# Altium schematic sheet CapSenseBottom.SchDoc
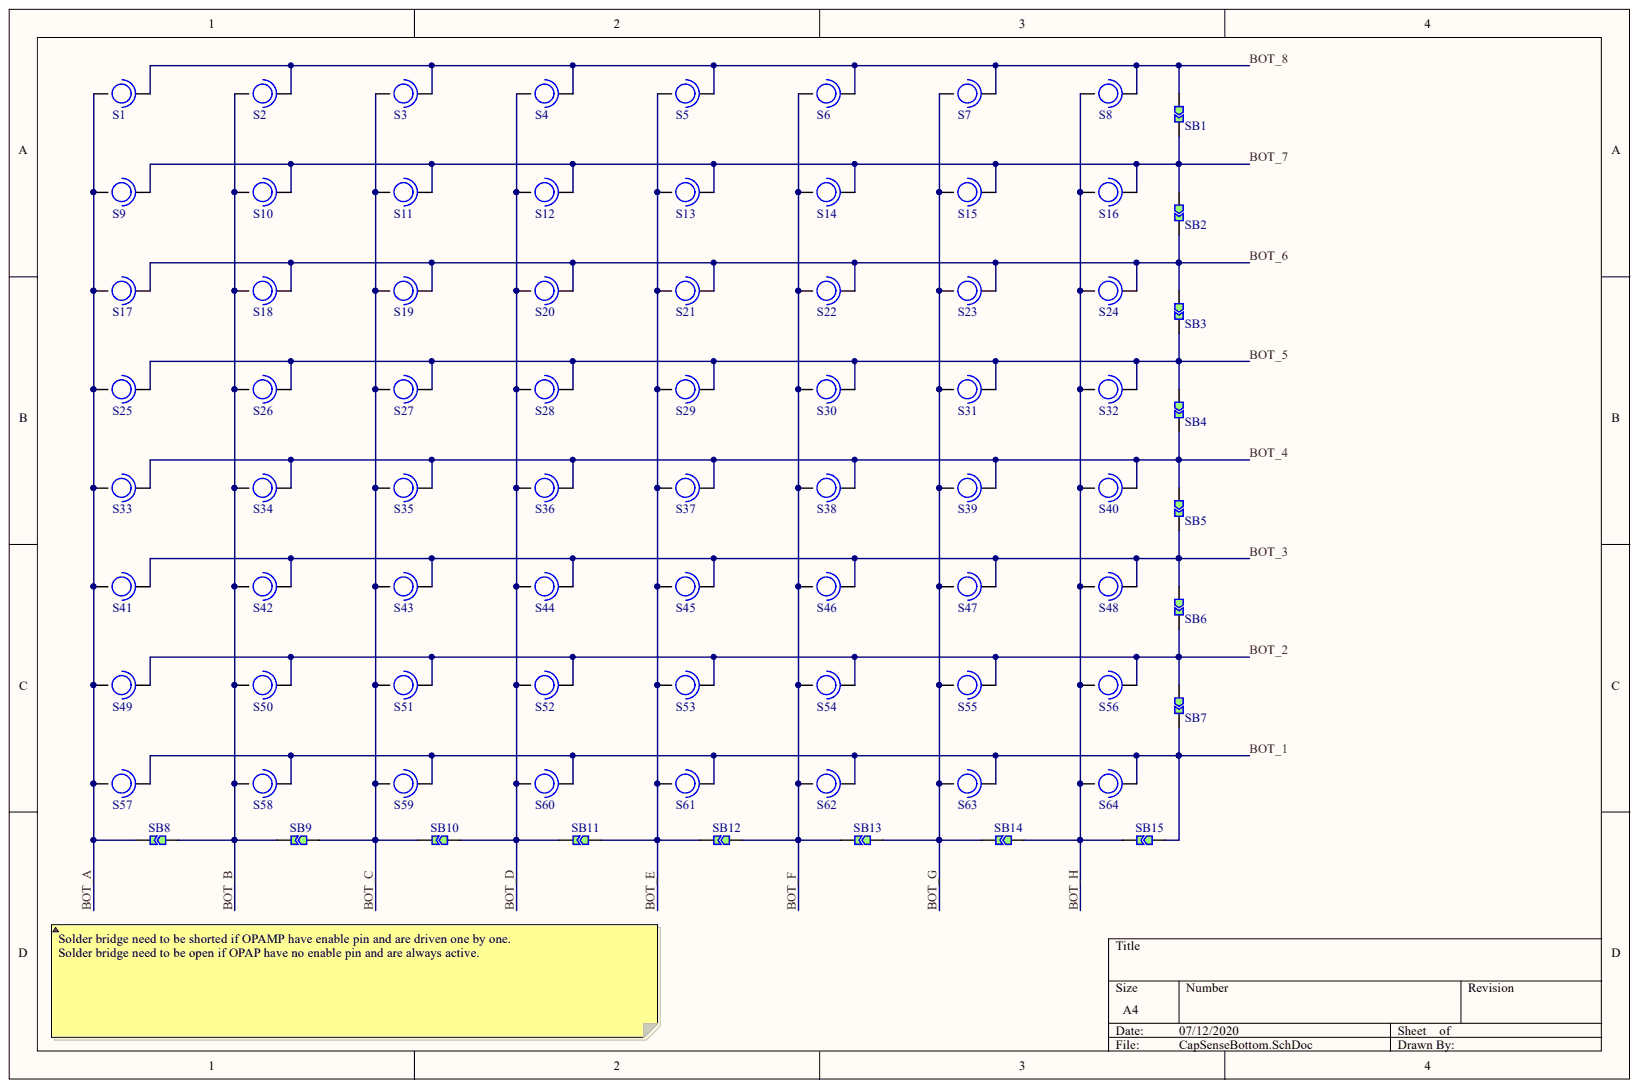
[diagram p0: CapSenseBottom.SchDoc]

## Parts
S1  sense_cap_chess_20mm  [PCB-23fkddm5n7nwthazufj0-1]  (value not drawn)
S2  sense_cap_chess_20mm  [PCB-23fkddm5n7nwthazufj0-1]  (value not drawn)
S3  sense_cap_chess_20mm  [PCB-23fkddm5n7nwthazufj0-1]  (value not drawn)
S4  sense_cap_chess_20mm  [PCB-23fkddm5n7nwthazufj0-1]  (value not drawn)
S5  sense_cap_chess_20mm  [PCB-23fkddm5n7nwthazufj0-1]  (value not drawn)
S6  sense_cap_chess_20mm  [PCB-23fkddm5n7nwthazufj0-1]  (value not drawn)
S7  sense_cap_chess_20mm  [PCB-23fkddm5n7nwthazufj0-1]  (value not drawn)
S8  sense_cap_chess_20mm  [PCB-23fkddm5n7nwthazufj0-1]  (value not drawn)
S9  sense_cap_chess_20mm  [PCB-23fkddm5n7nwthazufj0-1]  (value not drawn)
S10  sense_cap_chess_20mm  [PCB-23fkddm5n7nwthazufj0-1]  (value not drawn)
S11  sense_cap_chess_20mm  [PCB-23fkddm5n7nwthazufj0-1]  (value not drawn)
S12  sense_cap_chess_20mm  [PCB-23fkddm5n7nwthazufj0-1]  (value not drawn)
S13  sense_cap_chess_20mm  [PCB-23fkddm5n7nwthazufj0-1]  (value not drawn)
S14  sense_cap_chess_20mm  [PCB-23fkddm5n7nwthazufj0-1]  (value not drawn)
S15  sense_cap_chess_20mm  [PCB-23fkddm5n7nwthazufj0-1]  (value not drawn)
S16  sense_cap_chess_20mm  [PCB-23fkddm5n7nwthazufj0-1]  (value not drawn)
S17  sense_cap_chess_20mm  [PCB-23fkddm5n7nwthazufj0-1]  (value not drawn)
S18  sense_cap_chess_20mm  [PCB-23fkddm5n7nwthazufj0-1]  (value not drawn)
S19  sense_cap_chess_20mm  [PCB-23fkddm5n7nwthazufj0-1]  (value not drawn)
S20  sense_cap_chess_20mm  [PCB-23fkddm5n7nwthazufj0-1]  (value not drawn)
S21  sense_cap_chess_20mm  [PCB-23fkddm5n7nwthazufj0-1]  (value not drawn)
S22  sense_cap_chess_20mm  [PCB-23fkddm5n7nwthazufj0-1]  (value not drawn)
S23  sense_cap_chess_20mm  [PCB-23fkddm5n7nwthazufj0-1]  (value not drawn)
S24  sense_cap_chess_20mm  [PCB-23fkddm5n7nwthazufj0-1]  (value not drawn)
S25  sense_cap_chess_20mm  [PCB-23fkddm5n7nwthazufj0-1]  (value not drawn)
S26  sense_cap_chess_20mm  [PCB-23fkddm5n7nwthazufj0-1]  (value not drawn)
S27  sense_cap_chess_20mm  [PCB-23fkddm5n7nwthazufj0-1]  (value not drawn)
S28  sense_cap_chess_20mm  [PCB-23fkddm5n7nwthazufj0-1]  (value not drawn)
S29  sense_cap_chess_20mm  [PCB-23fkddm5n7nwthazufj0-1]  (value not drawn)
S30  sense_cap_chess_20mm  [PCB-23fkddm5n7nwthazufj0-1]  (value not drawn)
S31  sense_cap_chess_20mm  [PCB-23fkddm5n7nwthazufj0-1]  (value not drawn)
S32  sense_cap_chess_20mm  [PCB-23fkddm5n7nwthazufj0-1]  (value not drawn)
S33  sense_cap_chess_20mm  [PCB-23fkddm5n7nwthazufj0-1]  (value not drawn)
S34  sense_cap_chess_20mm  [PCB-23fkddm5n7nwthazufj0-1]  (value not drawn)
S35  sense_cap_chess_20mm  [PCB-23fkddm5n7nwthazufj0-1]  (value not drawn)
S36  sense_cap_chess_20mm  [PCB-23fkddm5n7nwthazufj0-1]  (value not drawn)
S37  sense_cap_chess_20mm  [PCB-23fkddm5n7nwthazufj0-1]  (value not drawn)
S38  sense_cap_chess_20mm  [PCB-23fkddm5n7nwthazufj0-1]  (value not drawn)
S39  sense_cap_chess_20mm  [PCB-23fkddm5n7nwthazufj0-1]  (value not drawn)
S40  sense_cap_chess_20mm  [PCB-23fkddm5n7nwthazufj0-1]  (value not drawn)
S41  sense_cap_chess_20mm  [PCB-23fkddm5n7nwthazufj0-1]  (value not drawn)
S42  sense_cap_chess_20mm  [PCB-23fkddm5n7nwthazufj0-1]  (value not drawn)
S43  sense_cap_chess_20mm  [PCB-23fkddm5n7nwthazufj0-1]  (value not drawn)
S44  sense_cap_chess_20mm  [PCB-23fkddm5n7nwthazufj0-1]  (value not drawn)
S45  sense_cap_chess_20mm  [PCB-23fkddm5n7nwthazufj0-1]  (value not drawn)
S46  sense_cap_chess_20mm  [PCB-23fkddm5n7nwthazufj0-1]  (value not drawn)
S47  sense_cap_chess_20mm  [PCB-23fkddm5n7nwthazufj0-1]  (value not drawn)
S48  sense_cap_chess_20mm  [PCB-23fkddm5n7nwthazufj0-1]  (value not drawn)
S49  sense_cap_chess_20mm  [PCB-23fkddm5n7nwthazufj0-1]  (value not drawn)
S50  sense_cap_chess_20mm  [PCB-23fkddm5n7nwthazufj0-1]  (value not drawn)
S51  sense_cap_chess_20mm  [PCB-23fkddm5n7nwthazufj0-1]  (value not drawn)
S52  sense_cap_chess_20mm  [PCB-23fkddm5n7nwthazufj0-1]  (value not drawn)
S53  sense_cap_chess_20mm  [PCB-23fkddm5n7nwthazufj0-1]  (value not drawn)
S54  sense_cap_chess_20mm  [PCB-23fkddm5n7nwthazufj0-1]  (value not drawn)
S55  sense_cap_chess_20mm  [PCB-23fkddm5n7nwthazufj0-1]  (value not drawn)
S56  sense_cap_chess_20mm  [PCB-23fkddm5n7nwthazufj0-1]  (value not drawn)
S57  sense_cap_chess_20mm  [PCB-23fkddm5n7nwthazufj0-1]  (value not drawn)
S58  sense_cap_chess_20mm  [PCB-23fkddm5n7nwthazufj0-1]  (value not drawn)
S59  sense_cap_chess_20mm  [PCB-23fkddm5n7nwthazufj0-1]  (value not drawn)
S60  sense_cap_chess_20mm  [PCB-23fkddm5n7nwthazufj0-1]  (value not drawn)
S61  sense_cap_chess_20mm  [PCB-23fkddm5n7nwthazufj0-1]  (value not drawn)
S62  sense_cap_chess_20mm  [PCB-23fkddm5n7nwthazufj0-1]  (value not drawn)
S63  sense_cap_chess_20mm  [PCB-23fkddm5n7nwthazufj0-1]  (value not drawn)
S64  sense_cap_chess_20mm  [PCB-23fkddm5n7nwthazufj0-1]  (value not drawn)
SB1  Solder Bridge 0402  [PCB-zgo6wgtf9tfvr4qcvek3-1]  (value not drawn)
SB2  Solder Bridge 0402  [PCB-zgo6wgtf9tfvr4qcvek3-1]  (value not drawn)
SB3  Solder Bridge 0402  [PCB-zgo6wgtf9tfvr4qcvek3-1]  (value not drawn)
SB4  Solder Bridge 0402  [PCB-zgo6wgtf9tfvr4qcvek3-1]  (value not drawn)
SB5  Solder Bridge 0402  [PCB-zgo6wgtf9tfvr4qcvek3-1]  (value not drawn)
SB6  Solder Bridge 0402  [PCB-zgo6wgtf9tfvr4qcvek3-1]  (value not drawn)
SB7  Solder Bridge 0402  [PCB-zgo6wgtf9tfvr4qcvek3-1]  (value not drawn)
SB8  Solder Bridge 0402  [PCB-zgo6wgtf9tfvr4qcvek3-1]  (value not drawn)
SB9  Solder Bridge 0402  [PCB-zgo6wgtf9tfvr4qcvek3-1]  (value not drawn)
SB10  Solder Bridge 0402  [PCB-zgo6wgtf9tfvr4qcvek3-1]  (value not drawn)
SB11  Solder Bridge 0402  [PCB-zgo6wgtf9tfvr4qcvek3-1]  (value not drawn)
SB12  Solder Bridge 0402  [PCB-zgo6wgtf9tfvr4qcvek3-1]  (value not drawn)
SB13  Solder Bridge 0402  [PCB-zgo6wgtf9tfvr4qcvek3-1]  (value not drawn)
SB14  Solder Bridge 0402  [PCB-zgo6wgtf9tfvr4qcvek3-1]  (value not drawn)
SB15  Solder Bridge 0402  [PCB-zgo6wgtf9tfvr4qcvek3-1]  (value not drawn)

## Nets
BOT_1: S57.2 S58.2 S59.2 S60.2 S61.2 S62.2 S63.2 S64.2 SB7.1 SB15.2
BOT_2: S49.2 S50.2 S51.2 S52.2 S53.2 S54.2 S55.2 S56.2 SB6.1 SB7.2
BOT_3: S41.2 S42.2 S43.2 S44.2 S45.2 S46.2 S47.2 S48.2 SB5.1 SB6.2
BOT_4: S33.2 S34.2 S35.2 S36.2 S37.2 S38.2 S39.2 S40.2 SB4.1 SB5.2
BOT_5: S25.2 S26.2 S27.2 S28.2 S29.2 S30.2 S31.2 S32.2 SB3.1 SB4.2
BOT_6: S17.2 S18.2 S19.2 S20.2 S21.2 S22.2 S23.2 S24.2 SB2.1 SB3.2
BOT_7: S9.2 S10.2 S11.2 S12.2 S13.2 S14.2 S15.2 S16.2 SB1.1 SB2.2
BOT_8: S1.2 S2.2 S3.2 S4.2 S5.2 S6.2 S7.2 S8.2 SB1.2
BOT_A: S1.1 S9.1 S17.1 S25.1 S33.1 S41.1 S49.1 S57.1 SB8.1
BOT_B: S2.1 S10.1 S18.1 S26.1 S34.1 S42.1 S50.1 S58.1 SB8.2 SB9.1
BOT_C: S3.1 S11.1 S19.1 S27.1 S35.1 S43.1 S51.1 S59.1 SB9.2 SB10.1
BOT_D: S4.1 S12.1 S20.1 S28.1 S36.1 S44.1 S52.1 S60.1 SB10.2 SB11.1
BOT_E: S5.1 S13.1 S21.1 S29.1 S37.1 S45.1 S53.1 S61.1 SB11.2 SB12.1
BOT_F: S6.1 S14.1 S22.1 S30.1 S38.1 S46.1 S54.1 S62.1 SB12.2 SB13.1
BOT_G: S7.1 S15.1 S23.1 S31.1 S39.1 S47.1 S55.1 S63.1 SB13.2 SB14.1
BOT_H: S8.1 S16.1 S24.1 S32.1 S40.1 S48.1 S56.1 S64.1 SB14.2 SB15.1

## Library data of the parts
S1-S64 = CMP-q6kamju61frmo791n94x-4: 20x20mm sensing footprint; Component Kind: Standard; Library Name: CommunityVault
SB1-SB15 = CMP-p5m5ndkvhoystooqawk9-5: Solder Bridge Jumper, can be fitted with 0402 0R resistor for automated production; State: Open / Closed; Component Kind: Standard; CustomComponentID: p5m5ndkvhoystooqawk9; Library Name: CommunityVault
